# Altium schematic sheet Ultrasound_Test_Prototype.SchDoc(Ultrasound_Test_Prototype)
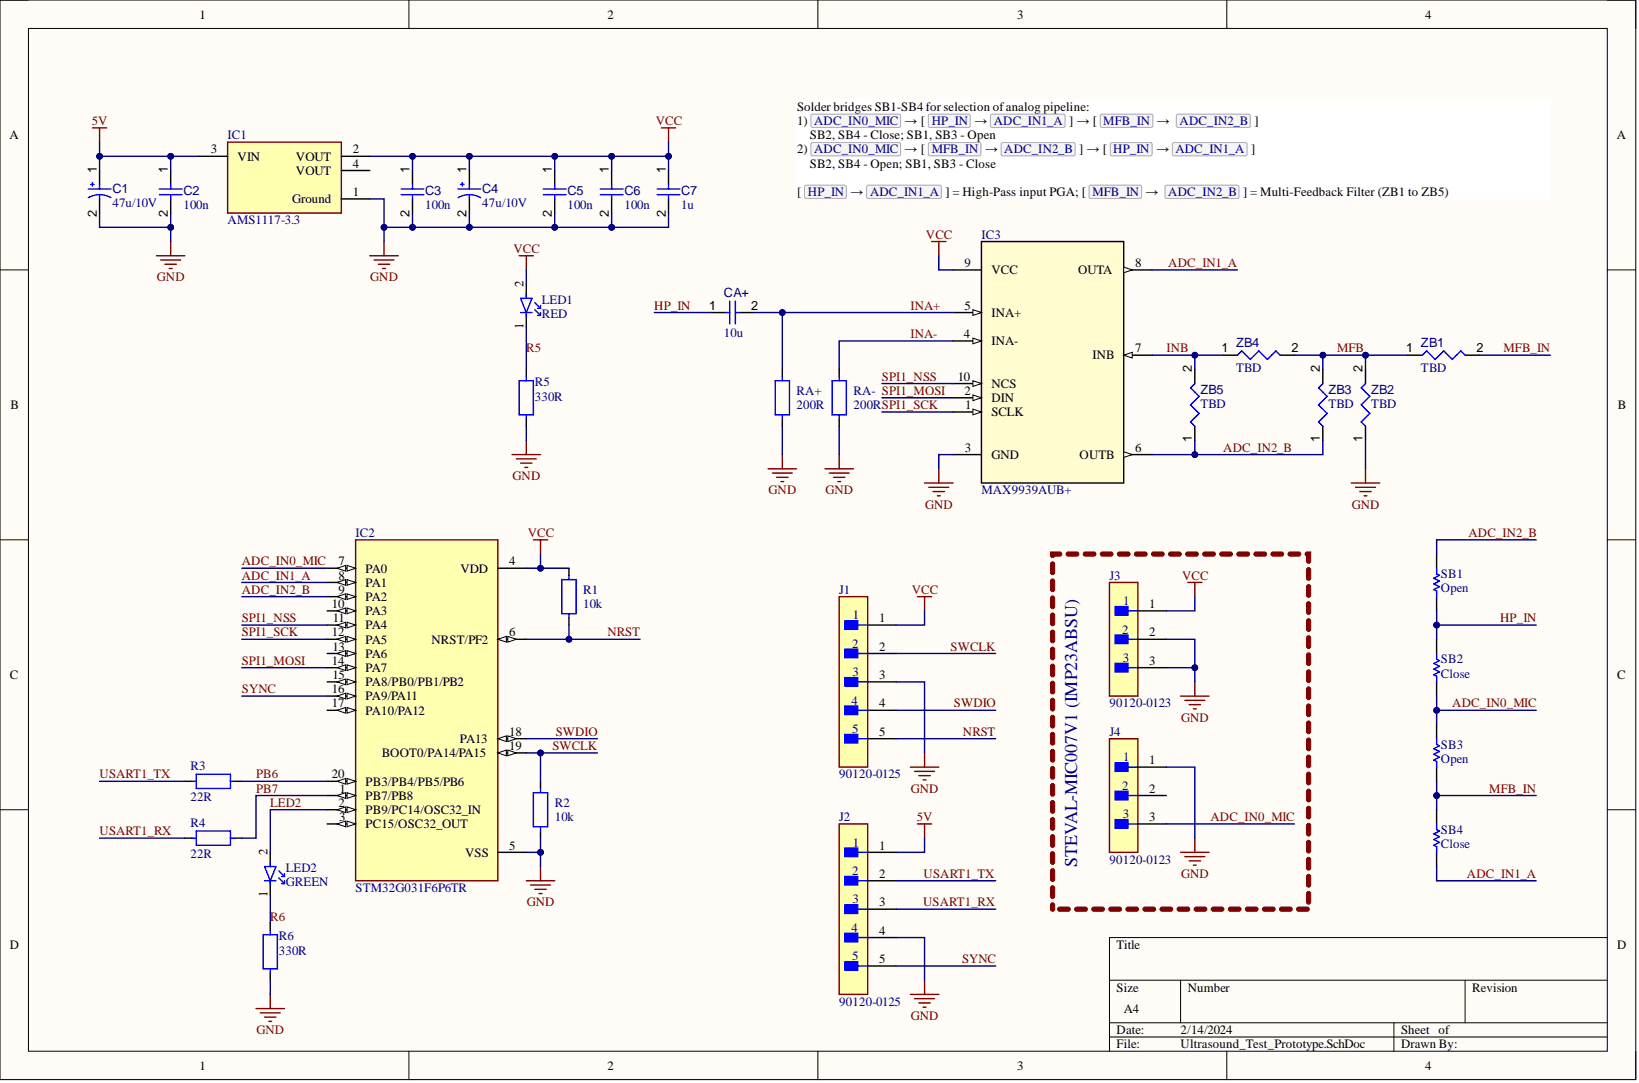
[diagram p0: Ultrasound_Test_Prototype.SchDoc]

## Parts
C1  value 47u/10V  (hidden comment: TAJC107K010RNJ)
C2  value 100n  (hidden comment: Capacitor 1µF +/-0.1% 25V 0603)
C3  value 100n  (hidden comment: Capacitor 1µF +/-0.1% 25V 0603)
C4  value 47u/10V  (hidden comment: TAJC107K010RNJ)
C5  value 100n  (hidden comment: Capacitor 1µF +/-0.1% 25V 0603)
C6  value 100n  (hidden comment: Capacitor 1µF +/-0.1% 25V 0603)
C7  value 1u  (hidden comment: Capacitor 1µF +/-0.1% 25V 0603)
CA+  value 10u  (hidden comment: Capacitor 1µF +/-0.1% 25V 0603)
IC1  AMS1117-3.3
IC2  STM32G031F6P6TR
IC3  MAX9939AUB+
J1  90120-0125
J2  90120-0125
J3  90120-0123
J4  90120-0123
LED1  RED
LED2  GREEN
R1  value 10k  (hidden comment: Resistor European)
R2  value 10k  (hidden comment: Resistor European)
R3  value 22R  (hidden comment: Resistor European)
R4  value 22R  (hidden comment: Resistor European)
R5  value 330R  (hidden comment: Resistor European)
R6  value 330R  (hidden comment: Resistor European)
RA+  value 200R  (hidden comment: Resistor European)
RA-  value 200R  (hidden comment: Resistor European)
SB1  value Open  (hidden comment: Resistor 0R +/- 5% 0402 100 mW)
SB2  value Close  (hidden comment: Resistor 0R +/- 5% 0402 100 mW)
SB3  value Open  (hidden comment: Resistor 0R +/- 5% 0402 100 mW)
SB4  value Close  (hidden comment: Resistor 0R +/- 5% 0402 100 mW)
ZB1  value TBD  (hidden comment: Resistor 10k +/-1% 0603 100 mW)
ZB2  value TBD  (hidden comment: Resistor 10k +/-1% 0603 100 mW)
ZB3  value TBD  (hidden comment: Resistor 10k +/-1% 0603 100 mW)
ZB4  value TBD  (hidden comment: Resistor 10k +/-1% 0603 100 mW)
ZB5  value TBD  (hidden comment: Resistor 10k +/-1% 0603 100 mW)

## Nets
5V: C1.1 C2.1 IC1.3(VIN) J2.1
GND: C1.2 C2.2 C3.2 C4.2 C5.2 C6.2 C7.2 IC1.1(Ground) IC2.5(VSS) IC3.3(GND) J1.3 J2.4 J3.2 J3.3 J4.1 R2.1 R5.2 R6.2 RA+.1 RA-.1 ZB2.1
VCC: C3.1 C4.1 C5.1 C6.1 C7.1 IC1.2(VOUT) IC2.4(VDD) IC3.9(VCC) J1.1 J3.1 LED1.2 R1.2
ADC_IN0_MIC: IC2.7(PA0) J4.3 SB2.1 SB3.2
ADC_IN1_A: IC2.8(PA1) IC3.8(OUTA) SB4.1
ADC_IN2_B: IC2.9(PA2) IC3.6(OUTB) SB1.2 ZB3.1 ZB5.1
HP_IN: CA+.1 SB1.1 SB2.2
INA+: CA+.2 IC3.5(INA+) RA+.2
INA-: IC3.4(INA-) RA-.2
INB: IC3.7(INB) ZB4.1 ZB5.2
LED2: IC2.2(PB9/PC14/OSC32_IN) LED2.2
MFB: ZB1.1 ZB2.2 ZB3.2 ZB4.2
MFB_IN: SB3.1 SB4.2 ZB1.2
NRST: IC2.6(NRST/PF2) J1.5 R1.1
PB6: IC2.20(PB3/PB4/PB5/PB6) R3.1
PB7: IC2.1(PB7/PB8) R4.1
R5: LED1.1 R5.1
R6: LED2.1 R6.1
SPI1_MOSI: IC2.14(PA7) IC3.2(DIN)
SPI1_NSS: IC2.11(PA4) IC3.10(NCS)
SPI1_SCK: IC2.12(PA5) IC3.1(SCLK)
SWCLK: IC2.19(BOOT0/PA14/PA15) J1.2 R2.2
SWDIO: IC2.18(PA13) J1.4
SYNC: IC2.16(PA9/PA11) J2.5
USART1_RX: J2.3 R4.2
USART1_TX: J2.2 R3.2

Not connected: IC1 4(VOUT); IC2 3(PC15/OSC32_OUT) 10(PA3) 13(PA6) 15(PA8/PB0/PB1/PB2) 17(PA10/PA12); J4 2

## Library data of the parts
C1 C4 = CMP-001-00045-7: Standard Tantalum, 100 uF, +/- 10%, 10 V, -55 to 125 degC, 2312 (6032 Metric), RoHS, Tape and Reel; Manufacturer: AVX; ESR: 1.2Ohm; Voltage Rating: 10V; Capacitor Type: Tantalum; Case/Package: 2412; Component Kind: Standard; Datasheet URL: http://datasheets.avx.com/TAJ.pdf; Datasheet Version: Feb-16; Library Name: My workspace; Manufacturer URL: http://www.avx.com/; Max Operating Temperature: 125°C; Min Operating Temperature: -55°C; Mounting Technology: SM; Package Description: 2-Pin Surface Mount Device, Body 6 x 3.25 mm; Package Quantity: 500; Package Reference: TAJ-C-2; Packaging: TapeandReel; Pins: 2; RoHS Compliant: True; Tolerance: 10%
C2 C3 C5-C7 CA+ = CMP-001-00055-4: Chip Capacitor, 1µF +/-0.1%, 25V, 0603, Thickness 1 mm; Tolerance: 20%; Case/Package: 0603; Component Kind: Standard; Library Name: My workspace; Max Operating Temperature: 85°C; Min Operating Temperature: -55°C; Mounting Technology: SMT; Pins: 2; Voltage Rating: 25V
IC1 = CMP-019-00055-1: Fixed Positive LDO Regulator, 3.3V, 1.3V Dropout, PDSO3; Component Kind: Standard; Library Name: My workspace; RoHS Compliant: Yes
IC2 = CMP-020-00030-1: Microcontroller 32-Bit ARM Cortex M0 32KByte Flash 1.7V to 3.6V 20-Pin TSSOP T/R; Clock Frequency: 64MHz; I/Os: 18; Nominal Supply Voltage: 3.3V; RAM Size: 8kB; Case/Package: TSSOP-20; Component Kind: Standard; Library Name: My workspace; Max Operating Temperature: 85°C; Max Supply Voltage: 3.6V; Min Operating Temperature: -40°C; Min Supply Voltage: 1.7V; Mounting Technology: SMD; Pins: 20
IC3 = CMP-014-00005-2: SPI-Programmable Gain Amplifier (PGA) with Input VOS Trim and Output Op Amp; Amplifier Type: OPERATIONAL AMPLIFIER; Channels: 1; Input Offset Voltage-Max: 15mV; Max Supply Current: 6.7mA; Nominal Supply Voltage: 5V; Package Body Material: Plastic; Package Equivalence Code: TSSOP10,.19,20; Power Supplies: 3/5V; Qualification Status: Not Qualified; Slew Rate: 6.4V/µs; Supply Voltage Limit-Max: 6V; Unity Gain BW-Nom: 2.2MHz; Case/Package: UMAX-10; Component Kind: Standard; Height - Seated (Max): 1.1mm; JESD-30 Code: S-PDSO-G10; JESD-609 Code: e3; Length: 3mm; Library Name: My workspace; Max Operating Temperature: 125°C; Min Operating Temperature: -40°C; Moisture Sensitivity Level: 1; Package Shape: Square; Package Style: SMALL OUTLINE, THIN PROFILE, SHRINK PITCHMeter; Pins: 10; Reach SVHC Compliant: Yes; RoHS Compliant: Yes; Surface Mount: Yes; Technology: BICMOS; Temperature Grade: AUTOMOTIVE; Terminal Finish: Tin; Terminal Form: Gull Wing; Terminal Pitch: 0.5mm; Terminal Position: DUAL; Width: 3mm
J1 J2 = CMP-002-00091-1: Board Connector, 5 Contact(s), 1 Row(s), Male, Straight, 0.1 inch Pitch, Solder Terminal, Black Insulator, Receptacle; Body Depth: 0.098inch; Body Length: 0.5inch; Contact Pattern: RECTANGULAR; Contact Resistance: 20mR; Contact Style: SQ PIN-SKT; Dielectric Withstanding Voltage (DC): 350V; DIN Conformance: No; Insertion Force-Max: 3.0024N; Insulation Resistance: 5GR; Mating Information: MULTIPLE MATING PARTS AVAILABLE; Number Of Connectors: 1; Number of Contacts: 5; Option: General Purpose; Plating Thickness: 160uin; Rated Current (Signal): 3A; Reference Standard: UL; Reliability: COMMERCIAL; Withdrawl Force-Min: 0.1946N; Body Breadth: 0.1inch; Body/Shell Style: RECEPTACLE; Component Kind: Standard; Connector Type: BOARD CONNECTOR; Contact Finish - Mating: NOT SPECIFIED; Contact Finish - Termination: Gold (Au); Contact Gender: Male; Contact Material: Copper Alloy; Filter Feature: No; Flammability Rating: UL94 V-0; IEC Conformance: No; Insulation Color: Black; Insulation Material: Glass; JESD-609 Code: e4; Library Name: My workspace; Mating Contact Pitch: 0.1inch; Max Operating Temperature: 125°C; MIL Conformance: No; Min Operating Temperature: -55°C; Mixed Contacts: No; Mounting Style: STRAIGHT; Mounting Technology: Board; Number Of PCB Rows: 1; Number of Rows Loaded: 1; PCB Contact Pattern: RECTANGULAR; Reach SVHC Compliant: Yes; RoHS Compliant: Yes; Terminal Length: 0.114inch; Terminal Pitch: 2.54mm; Termination: Solder
J3 J4 = CMP-002-00092-1: Board Connector, 3 Contact(s), 1 Row(s), Male, Straight, 0.1 inch Pitch, Solder Terminal, Black Insulator, Receptacle; Body Depth: 0.098inch; Body Length: 0.3inch; Contact Pattern: RECTANGULAR; Contact Resistance: 20mR; Contact Style: SQ PIN-SKT; Dielectric Withstanding Voltage (DC): 350V; DIN Conformance: No; Insertion Force-Max: 3.0024N; Insulation Resistance: 5GR; Mating Information: MULTIPLE MATING PARTS AVAILABLE; Number Of Connectors: 1; Number of Contacts: 3; Option: General Purpose; Plating Thickness: 160uin; Rated Current (Signal): 3A; Reference Standard: UL; Reliability: COMMERCIAL; Withdrawl Force-Min: 0.1946N; Body Breadth: 0.1inch; Body/Shell Style: RECEPTACLE; Component Kind: Standard; Connector Type: BOARD CONNECTOR; Contact Finish - Mating: NOT SPECIFIED; Contact Finish - Termination: Matte Tin (Sn) - with Nickel (Ni) barrier; Contact Gender: Male; Contact Material: Copper Alloy; Filter Feature: No; Flammability Rating: UL94 V-0; IEC Conformance: No; Insulation Color: Black; Insulation Material: Glass; JESD-609 Code: e3; Library Name: My workspace; Mating Contact Pitch: 0.1inch; Max Operating Temperature: 125°C; MIL Conformance: No; Min Operating Temperature: -55°C; Mixed Contacts: No; Mounting Style: STRAIGHT; Mounting Technology: Board; Number Of PCB Rows: 1; Number of Rows Loaded: 1; PCB Contact Pattern: RECTANGULAR; Reach SVHC Compliant: Yes; RoHS Compliant: Yes; Terminal Length: 0.114inch; Terminal Pitch: 2.54mm; Termination: Solder
LED1 LED2 = CMP-007-00036-1: Single Color LED, Red, Colorless Transparent, 1.4mm; Channels: 1; Forward Current: 25mA; Lens Style: Colorless,TRANSPARENT; Luminous Intensity-Nom: 4mcd; Overall Height: 0.8mm; Reverse Voltage-Max: 4V; Shape: Rectangular; Case/Package: 0805; Color: Red; Color@wavelength: Red; Component Kind: Standard; JESD-609 Code: e4; Library Name: My workspace; Max Operating Temperature: 85°C; Min Operating Temperature: -30°C; Mounting Technology: Surface Mount; Optoelectronic Device Type: SINGLE COLOR LED; Packaging: Bulk; Pins: 2; Reach SVHC Compliant: Yes; RoHS Compliant: Yes; Size: 1.4mm; Terminal Finish: Nickel; Terminal Pitch: 1.35mm
R1-R6 RA+ RA- = CMP-009-00177-6: Resistor European Symbol; Component Kind: Standard; Library Name: My workspace; Pins: 2
SB1-SB4 = CMP-009-00005-5: Chip Resistor, 0 Ohm, 0.1 W, -55 to 155 degC, 0402 (1005 Metric); Power: 100mW; Voltage Rating: 50V; Case/Package: 0402; Component Kind: Standard; Library Name: My workspace; Max Operating Temperature: 155°C; Min Operating Temperature: -55°C; Mounting Technology: SM; Pins: 2; RoHS Compliant: True; Tolerance: 5%
ZB1-ZB5 = CMP-009-00017-5: Chip Resistor, 10 KOhm, +/- 1%, 01 W, -55 to 155 degC, 0603 (1608 Metric); Power: 100mW; Voltage Rating: 50V; Case/Package: 0603; Component Kind: Standard; Library Name: My workspace; Max Operating Temperature: 155°C; Min Operating Temperature: -55°C; Mounting Technology: SM; Pins: 2; RoHS Compliant: True; Tolerance: 1%
